# Altium schematic sheet [11]-MAIN_POWER.SchDoc([11]-MAIN_POWER)
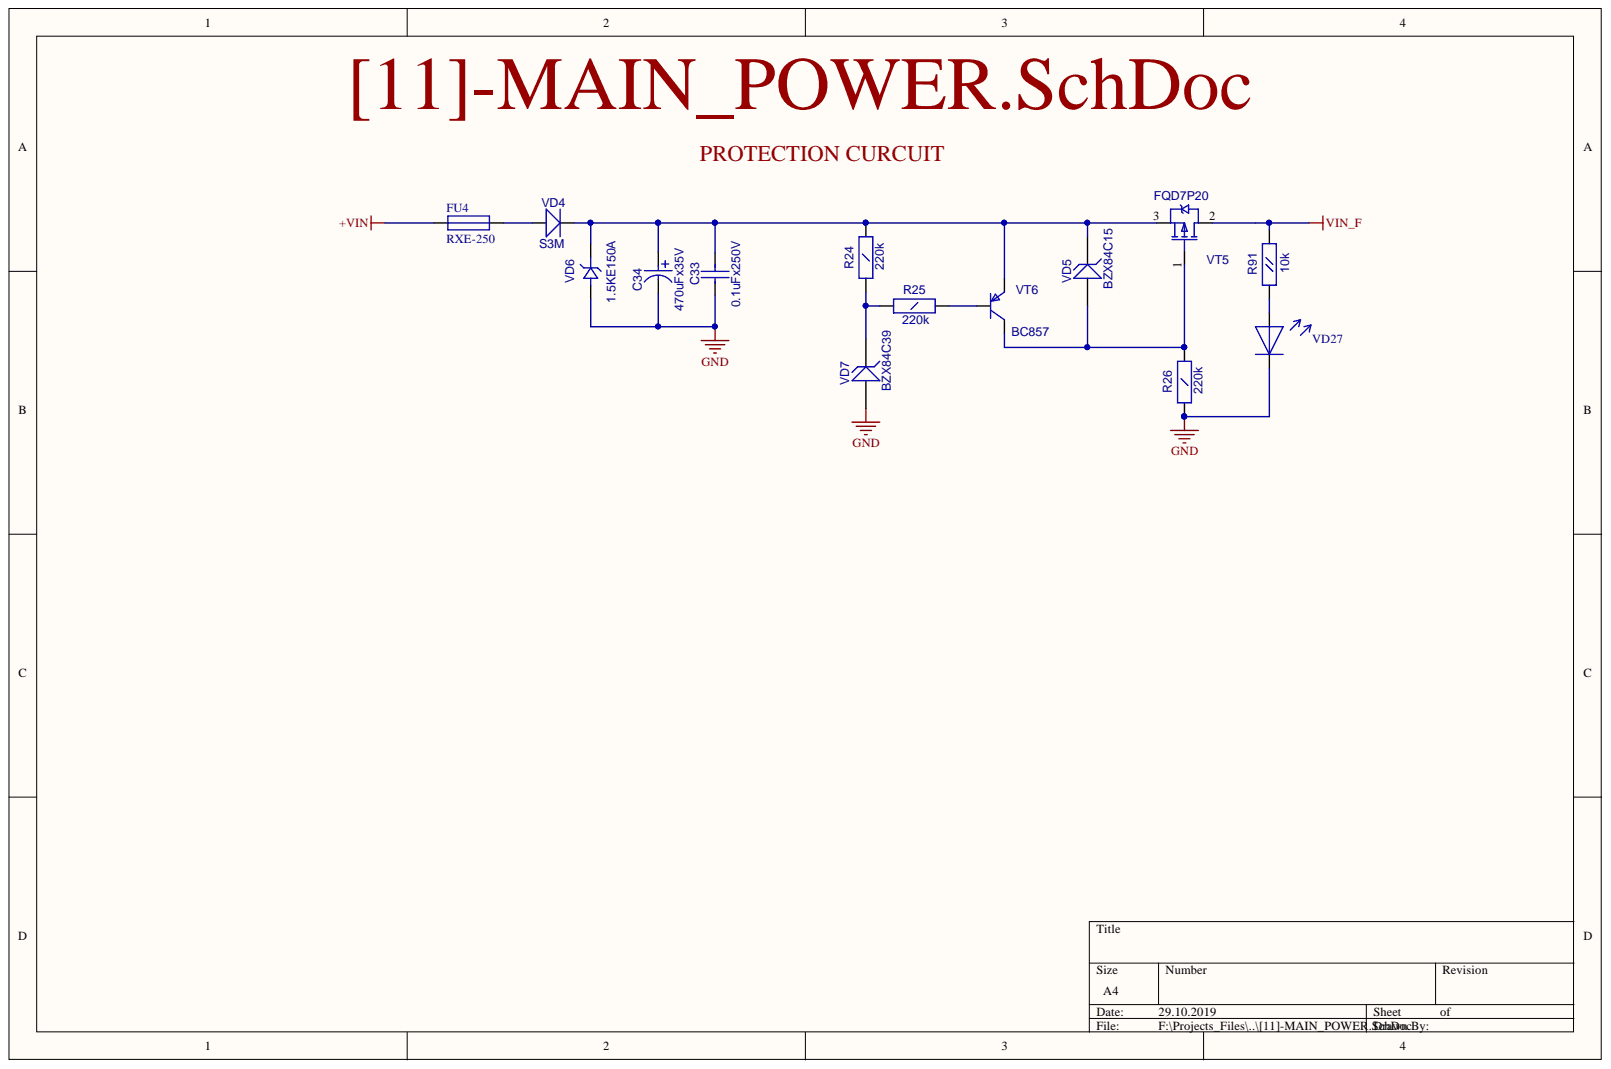
[diagram p0: [11]-MAIN_POWER.SchDoc]

## Parts
C33  0.1uFx250V  [CC1206]
C34  value 470uFx35V  [CC16X10_CAP0.5(1)(Primary)]  (hidden comment: CAP0.5(1))
FU4  RXE-250  [RXE-250_FLAT]
R24  220k  [RC1206]
R25  220k  [RC1206]
R26  220k  [RC1206]
R91  10k  [RC0603]
VD4  S3M  [SMC]
VD5  BZX84C15  [SOT-23(123)]
VD6  1.5KE150A  [DO-201_FLAT]
VD7  BZX84C39  [SOT-23(123)]
VD27  LED_0805  [LED0805]  (value not drawn)
VT5  FQD7P20  [D-PAK(TO-252AA)]
VT6  BC857  [SOT-23(123)]

## Nets
+VIN: FU4.1
GND: C33.1 C34.1 R26.1 VD6.1 VD7.1 VD27.2
VIN_F: R91.2 VT5.2
NetC33_2: C33.2 C34.2 R24.2 VD4.2 VD5.3 VD6.2 VT5.3 VT6.2
NetFU4_2: FU4.2 VD4.1
NetR24_1: R24.1 R25.1 VD7.3
NetR25_2: R25.2 VT6.1
NetR26_2: R26.2 VD5.1 VT5.1 VT6.3
NetR91_1: R91.1 VD27.1

## Library data of the parts
C33 = CC1206: Value: 0.1uFx250V; Description: CC1206; Component Kind: Standard; Library Name: gprs_modem_2.SchLib
R24-R26 = RC1206: Value: 220k; Description: RC1206; Component Kind: Standard; Library Name: fizik_lib.SchLib
R91 = RC0603: Value: 10k; Description: RC0603; Component Kind: Standard; Library Name: fizik_lib.SchLib
VD4 = S3A: Schottky Rectifier; Value: S3M; Component Kind: Standard; Library Name: fizik_lib.SchLib
VD5 VD7 = BZX84: Zener Voltage Regulator Diode; Component Kind: Standard; Library Name: fizik_lib.SchLib
  VD5: Value: BZX84C15
  VD7: Value: BZX84C39
VD6 = 1.5KE: Value: 1.5KE150A; Description: 1.5KE; Component Kind: Standard; Library Name: fizik_lib.SchLib
VT5 = IRFR9220: HEXFET Power MOSFET; OriginalName: IRLML5103; ComponentField7: Published 8-Jun-2000; Value: FQD7P20; Component Kind: Standard; Library Name: gprs_modem_2.SchLib
VT6 = BC857B: PNP 100ma transistor; OriginalName: BC846BLT1; ComponentField7: Published 29-Sep-2000; Value: BC857; Simulation: BC846BLT1; Component Kind: Standard; Library Name: gprs_modem_2.SchLib
C34 = CAP0.5(1): Description: CAP0.5(1); Component Kind: Standard; Library Name: gprs_modem_2.SchLib
FU4 = RXE-250: Value: RXE-250; Component Kind: Standard; Library Name: fizik_lib.SchLib
VD27 = LED_0805: Value: LED_0805; Component Kind: Standard; Library Name: fizik_lib.SchLib
